# Altium schematic sheet [5]_HDMI.SchDoc([5]_HDMI)
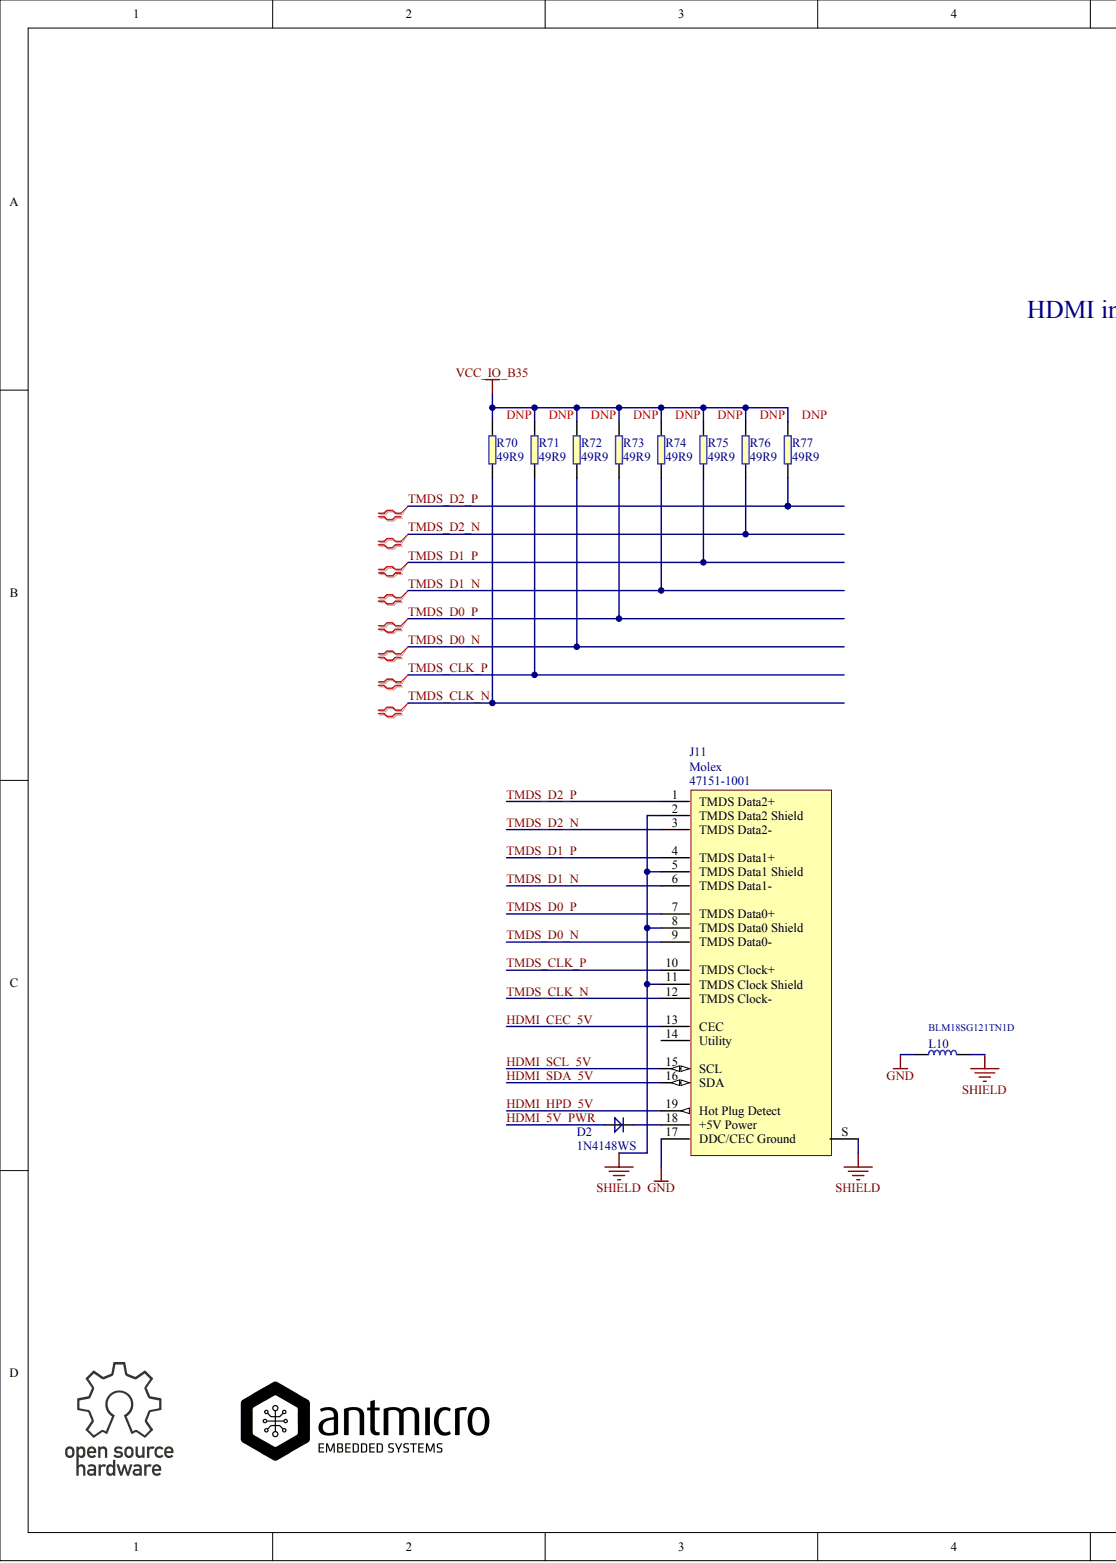
[diagram p0: [5]_HDMI.SchDoc, left]
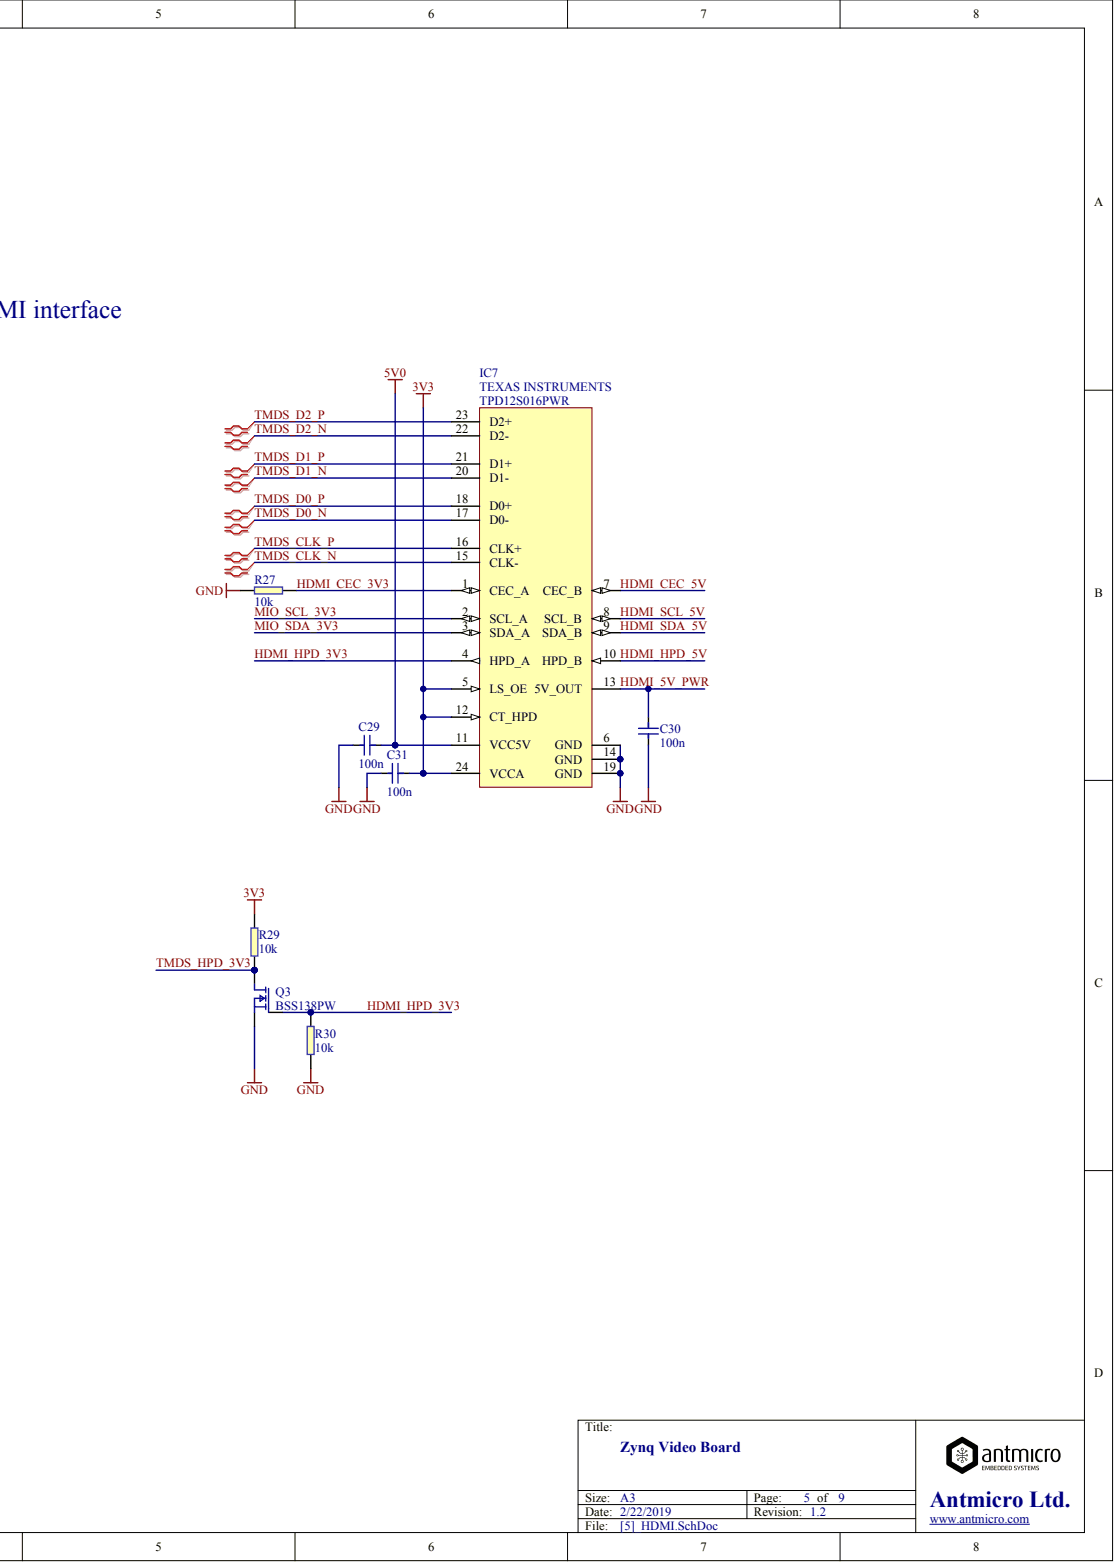
[diagram p1: [5]_HDMI.SchDoc, right]

## Parts
C29  100n
C30  100n
C31  100n
D2  1N4148WS
IC7  Manufacturer: TEXAS INSTRUMENTS  (hidden comment: TPD12S016PWR)
J11  Manufacturer: Molex  [Molex_HDMI_471511001]  (hidden comment: 47151-1001)
L10  BLM18SG121TN1D
Q3  BSS138PW
R27  10k
R29  10k
R30  10k
R70  49R9
R71  49R9
R72  49R9
R73  49R9
R74  49R9
R75  49R9
R76  49R9
R77  49R9

## Nets
3V3: C31.1 IC7.5(LS_OE) IC7.12(CT_HPD) IC7.24(VCCA) R29.2
5V0: C29.1 IC7.11(VCC5V)
GND: C29.2 C30.2 C31.2 IC7.6(GND) IC7.14(GND) IC7.19(GND) J11.17 L10.1 Q3.2 R27.1 R30.1
SHIELD: J11.2(TMDS_Data2_Shield) J11.5(TMDS_Data1_Shield) J11.8(TMDS_Data0_Shield) J11.11(TMDS_Clock_Shield) J11.S L10.2
VCC_IO_B35: R70.2 R71.2 R72.2 R73.2 R74.2 R75.2 R76.2 R77.2
HDMI_5V_PWR: C30.1 D2.A IC7.13(5V_OUT)
HDMI_CEC_3V3: IC7.1(CEC_A) R27.2
HDMI_CEC_5V: IC7.7(CEC_B) J11.13(CEC)
HDMI_HPD_3V3: IC7.4(HPD_A) Q3.1 R30.2
HDMI_HPD_5V: IC7.10(HPD_B) J11.19(Hot_Plug_Detect)
HDMI_SCL_5V: IC7.8(SCL_B) J11.15(SCL)
HDMI_SDA_5V: IC7.9(SDA_B) J11.16(SDA)
MIO_SCL_3V3: IC7.2(SCL_A)
MIO_SDA_3V3: IC7.3(SDA_A)
TMDS_CLK_N: IC7.15(CLK-) J11.12(TMDS_Clock-) R70.1
TMDS_CLK_P: IC7.16(CLK+) J11.10(TMDS_Clock+) R71.1
TMDS_D0_N: IC7.17(D0-) J11.9(TMDS_Data0-) R72.1
TMDS_D0_P: IC7.18(D0+) J11.7(TMDS_Data0+) R73.1
TMDS_D1_N: IC7.20(D1-) J11.6(TMDS_Data1-) R74.1
TMDS_D1_P: IC7.21(D1+) J11.4(TMDS_Data1+) R75.1
TMDS_D2_N: IC7.22(D2-) J11.3(TMDS_Data2-) R76.1
TMDS_D2_P: IC7.23(D2+) J11.1(TMDS_Data2+) R77.1
TMDS_HPD_3V3: Q3.3 R29.1
NetD2_C: D2.C J11.18(+5V_Power)

Not connected: J11 14(Utility)

## Library data of the parts
C29-C31 = (no symbol name): Part Number: C_100n_0402; Manufacturer: MULTICOMP; Part Symbol: MC0402X104M100CT; Voltage: 10V; Tolerance: +-20%; Value: 100n
D2 = (no symbol name): Manufacturer: ON SEMICONDUCTOR; Part Number: 1N4148WS; Value: 1N4148WS; Part Symbol: 1N4148WS
J11 = CON-Molex-471511001: Part Number: CON-Molex-471511001; Pin Count: 19; Value: 47151-1001
L10 = (no symbol name): Manufacturer: MURATA; Rated Current: 3A; Part Number: BLM18SG121TN1D; Value: BLM18SG121TN1D; Part Symbol: BLM18SG121TN1D
Q3 = (no symbol name): Manufacturer: NXP; Value: BSS138PW; Part Number: BSS138PW; Part Symbol: BSS138PW
R27 R29 R30 = (no symbol name): Part Number: R_10k_0402; Manufacturer: MULTICOMP; Part Symbol: MCMR04X1002FTL; Tolerance: 1%; Value: 10k
R70-R77 = (no symbol name): Part Number: R_49R9_0402; Manufacturer: VISHAY; Part Symbol: CRCW040249R9FKED; Tolerance: 1%; Value: 49R9
IC7 = (no symbol name): Part Number: TPD12S016PWR; Value: TPD12S016PWR; Part Symbol: TPD12S016PWR
